# Altium schematic sheet supply.SchDoc(supply)
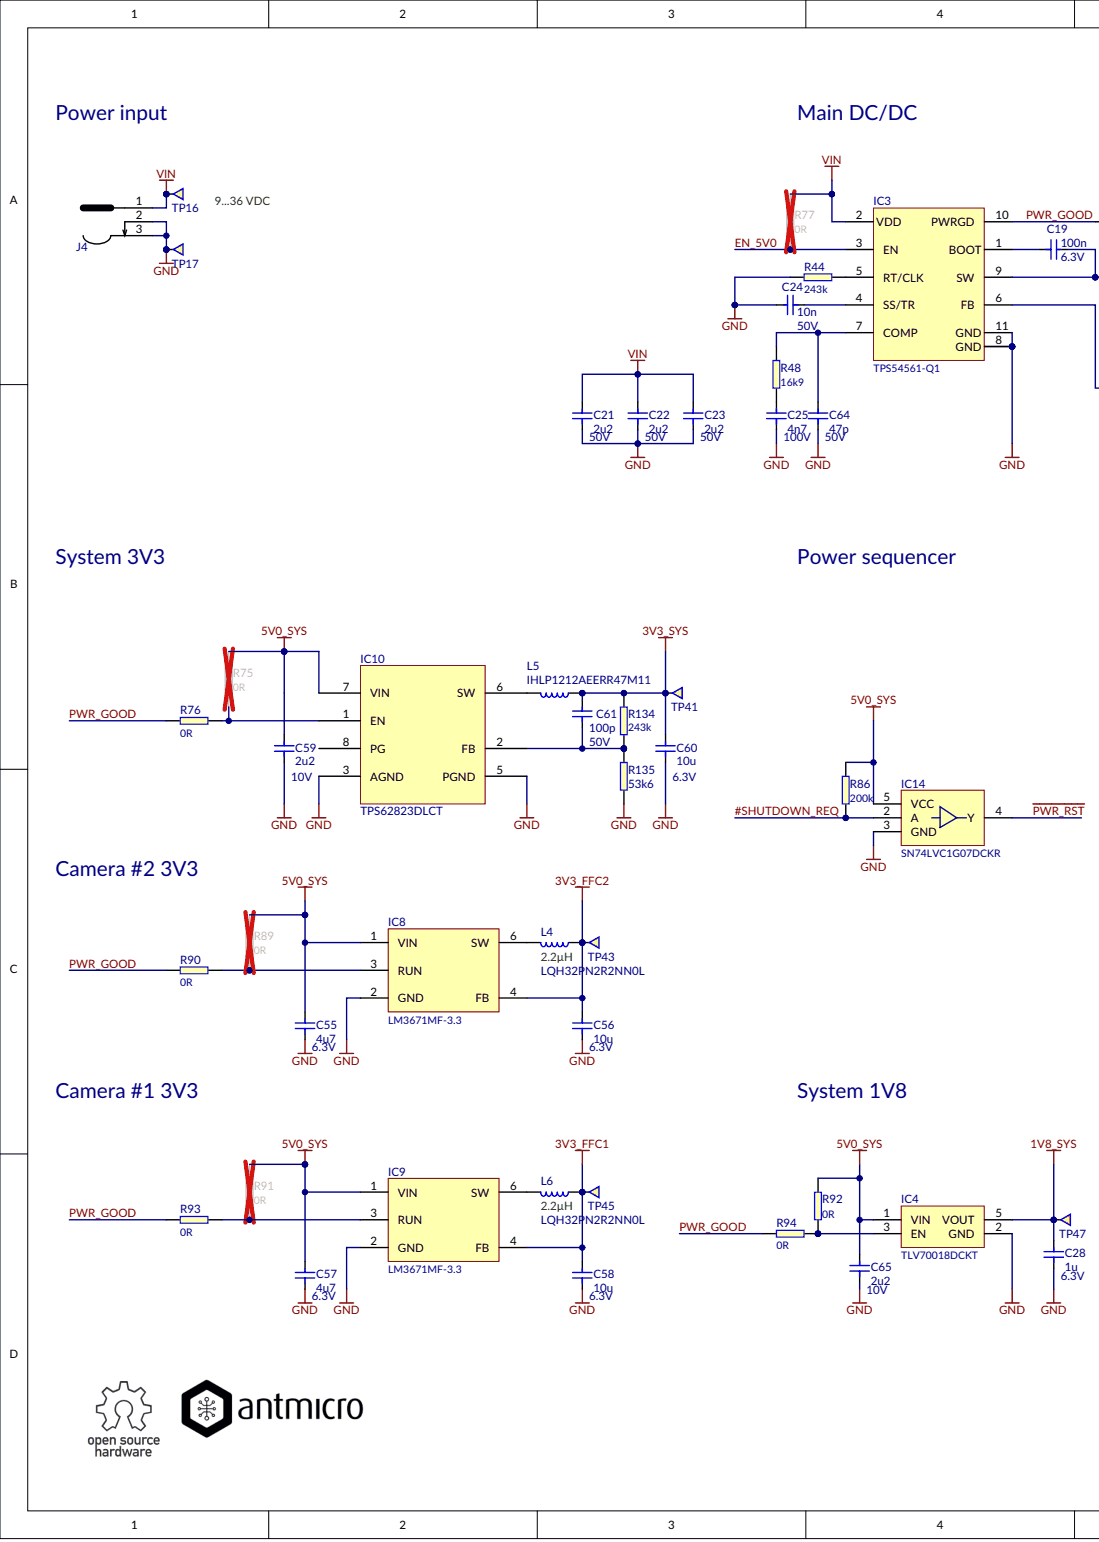
[diagram p0: supply.SchDoc, left]
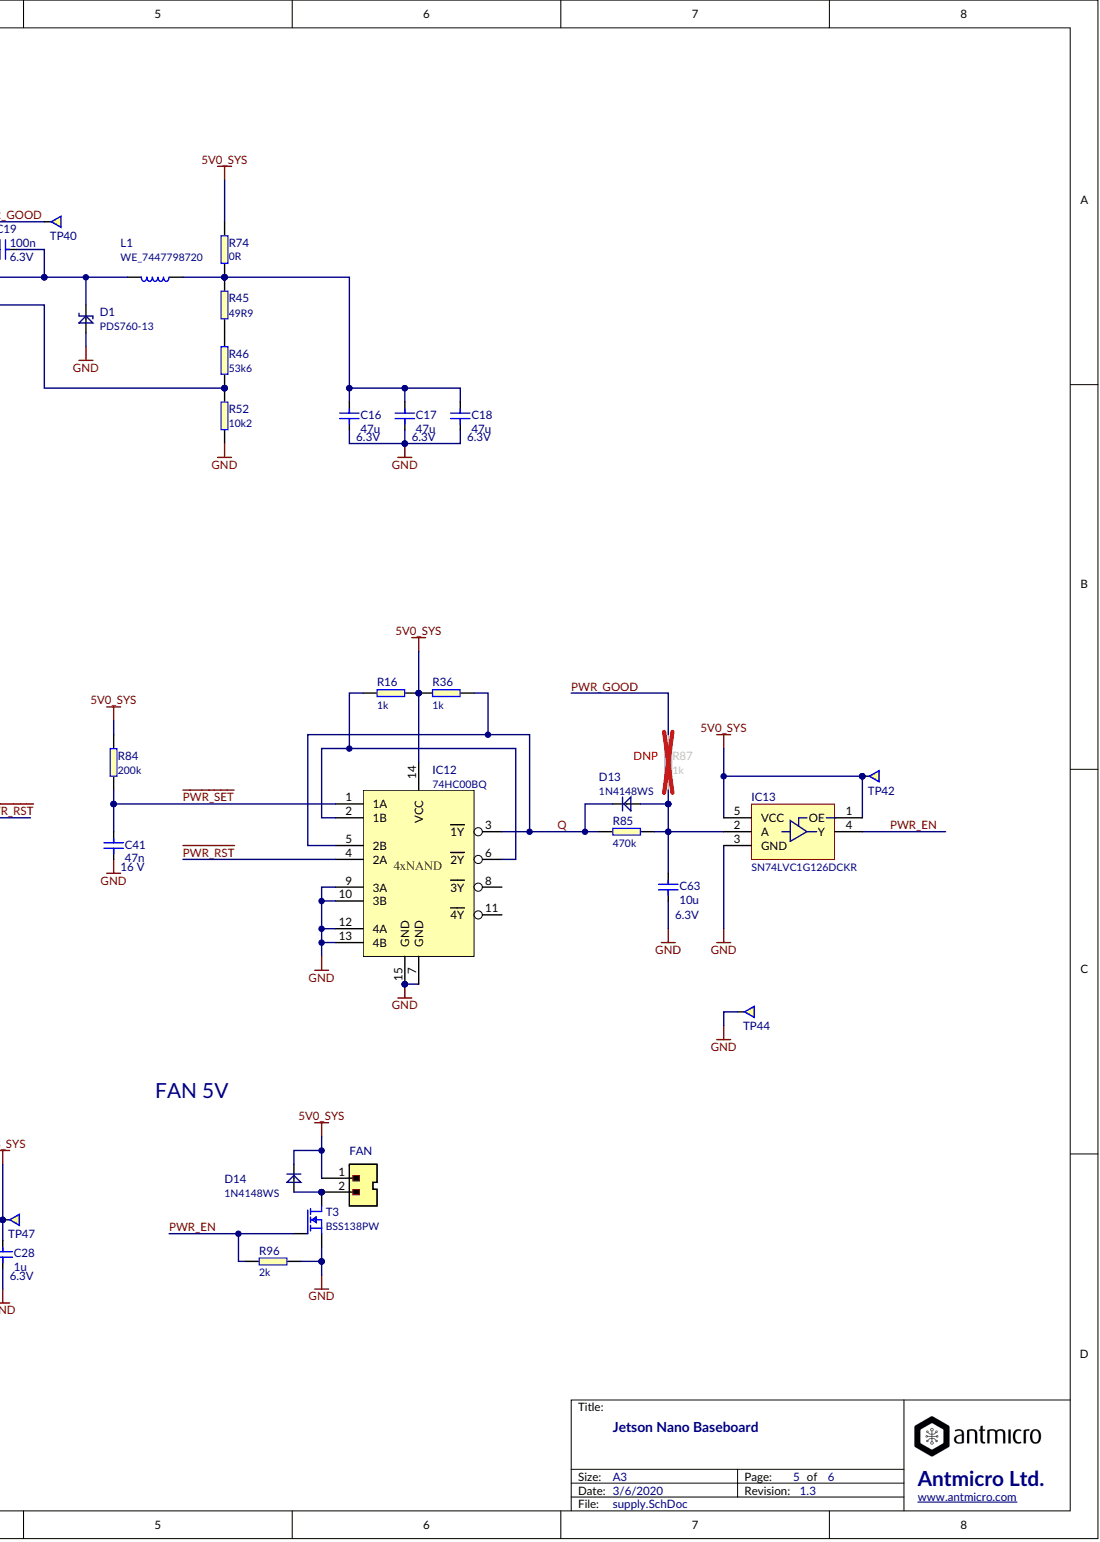
[diagram p1: supply.SchDoc, right]

## Parts
C16  Voltage: 6.3V  (hidden comment: 47u)
C17  Voltage: 6.3V  (hidden comment: 47u)
C18  Voltage: 6.3V  (hidden comment: 47u)
C19  Voltage: 6.3V  (hidden comment: 100n)
C21  Voltage: 50V  (hidden comment: 2u2)
C22  Voltage: 50V  (hidden comment: 2u2)
C23  Voltage: 50V  (hidden comment: 2u2)
C24  Voltage: 50V  (hidden comment: 10n)
C25  Voltage: 100V  (hidden comment: 4n7)
C28  Voltage: 6.3V  (hidden comment: 1u)
C41  Voltage: 16 V  (hidden comment: 47n)
C55  Voltage: 6.3V  (hidden comment: 4u7)
C56  Voltage: 6.3V  (hidden comment: 10u)
C57  Voltage: 6.3V  (hidden comment: 4u7)
C58  Voltage: 6.3V  (hidden comment: 10u)
C59  2u2
C60  Voltage: 6.3V  (hidden comment: 10u)
C61  Voltage: 50V  (hidden comment: 100p)
C63  Voltage: 6.3V  (hidden comment: 10u)
C64  Voltage: 50V  (hidden comment: 47p)
C65  Voltage: 10V  (hidden comment: 2u2)
D1  PDS760-13
D13  1N4148WS
D14  1N4148WS
FAN  MX-22-23-2021  (value not drawn)
IC3  TPS54561-Q1
IC4  TLV70018DCKT
IC8  LM3671MF-3.3
IC9  LM3671MF-3.3
IC10  TPS62823DLCT
IC12  74HC00BQ
IC13  SN74LVC1G126DCKR
IC14  SN74LVC1G07DCKR
J4  MJ-179PH  (value not drawn)
L1  WE_7447798720
L4  LQH32PN2R2NN0L  (value not drawn)
L5  IHLP1212AEERR47M11
L6  LQH32PN2R2NN0L  (value not drawn)
R16  1k
R36  1k
R44  243k
R45  49R9
R46  53k6
R48  16k9
R52  10k2
R74  0R
R75  0R
R76  0R
R77  0R
R84  200k
R85  470k
R86  200k
R87  1k
R89  0R
R90  0R
R91  0R
R92  0R
R93  0R
R94  0R
R96  2k
R134  243k
R135  53k6
T3  BSS138PW
TP16  Testpad  (value not drawn)
TP17  Testpad  (value not drawn)
TP40  Testpad  (value not drawn)
TP41  Testpad  (value not drawn)
TP42  Testpad  (value not drawn)
TP43  Testpad  (value not drawn)
TP44  Testpad  (value not drawn)
TP45  Testpad  (value not drawn)
TP47  Testpad  (value not drawn)

## Nets
1V8_SYS: C28.2 IC4.5(VOUT) TP47.1
3V3_FFC1: C58.2 IC9.4(FB) L6.2 TP45.1
3V3_FFC2: C56.2 IC8.4(FB) L4.2 TP43.1
3V3_SYS: C60.2 C61.2 L5.2 R134.1 TP41.1
5V0_SYS: C55.2 C57.2 C59.2 C65.2 D14.C FAN.1 IC4.1(VIN) IC8.1(VIN) IC9.1(VIN) IC10.7(VIN) IC12.14(VCC) IC13.1(OE) IC13.5(VCC) IC14.5(VCC) R16.1 R36.2 R74.2 R75.2 R84.2 R86.2 R89.2 R91.2 R92.1 TP42.1
GND: C16.1 C17.1 C18.1 C21.1 C22.1 C23.1 C24.2 C25.1 C28.1 C41.1 C55.1 C56.1 C57.1 C58.1 C59.1 C60.1 C63.1 C64.1 C65.1 D1.A IC3.8(GND) IC3.11(GND) IC4.2(GND) IC8.2(GND) IC9.2(GND) IC10.3(AGND) IC10.5(PGND) IC12.7(GND) IC12.9(3A) IC12.10(3B) IC12.12(4A) IC12.13(4B) IC12.15(GND) IC13.3(GND) IC14.3(GND) J4.2 J4.3 R44.2 R52.1 R96.2 R135.1 T3.2 TP17.1 TP44.1
VIN: C21.2 C22.2 C23.2 IC3.2(VDD) J4.1 R77.2 TP16.1
#SHUTDOWN_REQ: IC14.2(A) R86.1
~{PWR_RST}: IC12.4(2A) IC14.4(Y)
~{PWR_SET}: C41.2 IC12.1(1A) R84.1
EN_5V0: IC3.3(EN) R77.1
PWR_EN: IC13.4(Y) R96.1 T3.1
PWR_GOOD: IC3.10(PWRGD) R76.2 R87.1 R90.2 R93.2 R94.2 TP40.1
Q: D13.C IC12.3(1Y) IC12.5(2B) R36.1 R85.1
NetC16_2: C16.2 C17.2 C18.2 L1.2 R45.2 R74.1
NetC19_1: C19.1 IC3.1(BOOT)
NetC19_2: C19.2 D1.C IC3.9(SW) L1.1
NetC24_1: C24.1 IC3.4(SS/TR)
NetC25_2: C25.2 R48.1
NetC61_1: C61.1 IC10.2(FB) R134.2 R135.2
NetC63_2: C63.2 D13.A IC13.2(A) R85.2 R87.2
NetC64_2: C64.2 IC3.7(COMP) R48.2
NetD14_A: D14.A FAN.2 T3.3
NetIC3_5: IC3.5(RT/CLK) R44.1
NetIC3_6: IC3.6(FB) R46.1 R52.2
NetIC4_3: IC4.3(EN) R92.2 R94.1
NetIC8_3: IC8.3(RUN) R89.1 R90.1
NetIC8_6: IC8.6(SW) L4.1
NetIC9_3: IC9.3(RUN) R91.1 R93.1
NetIC9_6: IC9.6(SW) L6.1
NetIC10_1: IC10.1(EN) R75.1 R76.1
NetIC10_6: IC10.6(SW) L5.1
NetIC12_2: IC12.2(1B) IC12.6(2Y) R16.2
NetR45_1: R45.1 R46.2

Not connected: IC10 8(PG); IC12 8(3Y) 11(4Y)

## Library data of the parts
C16-C18 = (no symbol name): PartNumber: C_47u_0805; Manufacturer: KEMET; PartSymbol: C0805C476M9PACTU; Value: 47u
C19 = (no symbol name): Manufacturer: TDK; PartNumber: C_100n_0402; PartSymbol: C1005X5R0J104K050BA; Value: 100n
C21-C23 = (no symbol name): PartNumber: C_2u2_1206; Manufacturer: KEMET; PartSymbol: C1206C225J5RACTU; Value: 2u2
C24 = (no symbol name): PartNumber: C_10n_0402; Manufacturer: AVX; PartSymbol: 04025G103ZAT2A; Value: 10n
C25 = (no symbol name): PartNumber: C_4n7_0402; Manufacturer: TDK; PartSymbol: CGA2B3X7S2A472K050BB; Value: 4n7
C28 = (no symbol name): Manufacturer: MULTICOMP; PartNumber: C_1u_0402; PartSymbol: MC0402F105Z6R3CT; Value: 1u
C41 = (no symbol name): PartNumber: C_47n_0402; Manufacturer: MULTICOMP; PartSymbol: MC0402X473K160CT; Value: 47n
C55 C57 = (no symbol name): Manufacturer: MULTICOMP; PartNumber: C_4u7_0603; PartSymbol: MC0603X475K6R3CT; Value: 4u7
C56 C58 C60 C63 = (no symbol name): Manufacturer: Walsin; PartNumber: C_10u_0603; PartSymbol: 0603X106M6R3CT; Value: 10u
C59 = (no symbol name): Manufacturer: TDK; PartNumber: C_2u2_0402; PartSymbol: C1005X5R1A225K050BC; Voltage: 10V; Value: 2u2
C61 = (no symbol name): PartNumber: C_100p_0402; Manufacturer: WALSIN; PartSymbol: 0402N101J500CT; Class: Capacitor; Value: 100p
C64 = (no symbol name): PartNumber: C_47p_0402; Manufacturer: KEMET; PartSymbol: C0402C470J5GACTU; Value: 47p
C65 = (no symbol name): Manufacturer: TDK; PartNumber: C_2u2_0402; PartSymbol: C1005X5R1A225K050BC; Value: 2u2
D1 = (no symbol name): Manufacturer: DIODES INC.; PartNumber: PDS760-13; Value: PDS760-13; PartSymbol: PDS760-13
D13 D14 = (no symbol name): Manufacturer: ON SEMICONDUCTOR; PartNumber: 1N4148WS; Value: 1N4148WS; PartSymbol: 1N4148WS
FAN = (no symbol name): Manufacturer: Molex; PartNumber: molex_2223-2021; PartSymbol: 022232021 A-6373-02A222; Value: MX-22-23-2021
IC3 IC4 IC13 = (no symbol name): Manufacturer: Texas Instruments
  IC3: PartNumber: TPS54561-Q1; Value: TPS54561-Q1; PartSymbol: TPS54561-Q1
  IC4: Value: TLV70018DCKT; PartNumber: TLV70018DCKT; PartSymbol: TLV70018DCKT
  IC13: PartNumber: SN74LVC1G126DCKR; Value: SN74LVC1G126DCKR; PartSymbol: SN74LVC1G126DCKR
IC8 IC9 = (no symbol name): Manufacturer: Texas Instruments; PartSymbol: LM3671MF-3.3/NOPB; Value: LM3671MF-3.3; PartNumber: LM3671MF-3.3
IC10 = (no symbol name): Manufacturer: TEXAS INSTRUMENTS; Class: IC; PartNumber: TPS62823; Value: TPS62823DLCT; PartSymbol: TPS62823DLCT
IC12 = (no symbol name): Manufacturer: NEXPERIA; PartNumber: 74HC00BQ; Value: 74HC00BQ; PartSymbol: 74HC00BQ
IC14 = (no symbol name): Manufacturer: Texas Instruments; PartSymbol: SN74LVC1G07GW; PartNumber: SN74LVC1G07DCKR; Value: SN74LVC1G07DCKR
J4 = (no symbol name): PartNumber: DC_SOCKET; Manufacturer: MULTICOMP; PartSymbol: MJ-179PH; Value: MJ-179PH
L1 = (no symbol name): Manufacturer: WURTH ELEKTRONIK; RatedCurrent: 7.9A; Inductance: 7.2uH; PartNumber: WE_7447798720; Value: WE_7447798720; PartSymbol: 7447798720
L4 L6 = (no symbol name): Manufacturer: MURATA; Inductance: 2.2uH; PartNumber: LQH32PN2R2NN0L; Value: LQH32PN2R2NN0L; PartSymbol: LQH32PN2R2NN0L
L5 = (no symbol name): Manufacturer: VISHAY; RatedCurrent: 6.7A; Inductance: 470nH; Class: Inductance; PartNumber: IHLP1212AEERR47M11; Value: IHLP1212AEERR47M11; PartSymbol: IHLP1212AEERR47M11
R16 R36 R87 = (no symbol name): PartNumber: R_1k_0402; Manufacturer: BOURNS; PartSymbol: CR0402-FX-1001GLF; Value: 1k
R44 R134 = (no symbol name): PartNumber: R_243k_0402; Manufacturer: MULTICOMP; PartSymbol: MCMR04X2433FTL; Value: 243k
R45 = (no symbol name): PartNumber: R_49R9_0402; Manufacturer: VISHAY; PartSymbol: CRCW040249R9FKED; Value: 49R9
R46 = (no symbol name): PartNumber: R_53k6_0402; Manufacturer: TE Connectivity; PartSymbol: CPF0402B53K6E1; Value: 53k6
R48 = (no symbol name): PartNumber: R_16k9_0402; Manufacturer: Panasonic; PartSymbol: ERA2AEB1692X; Value: 16k9
R52 = (no symbol name): PartNumber: R_10k2_0402_0.1%; Manufacturer: TE Connectivity; PartSymbol: CPF0402B10K2E1; Tolerance: 0.1%; Value: 10k2
R74 = (no symbol name): Manufacturer: Panasonic; PartNumber: R_0R_0402; PartSymbol: ERJ2GE0R00X; Value: 0R
R75-R77 R89-R94 = (no symbol name): PartNumber: R_0R_0402; Manufacturer: Panasonic; PartSymbol: ERJ2GE0R00X; Value: 0R
R84 R86 = (no symbol name): PartNumber: R_200k_0402; Manufacturer: YAGEO; PartSymbol: RC0402FR-07200KL; Value: 200k
R85 = (no symbol name): PartNumber: R_470k_0603; Manufacturer: VISHAY; PartSymbol: CRCW0603470KFKEA; Value: 470k
R96 = (no symbol name): PartNumber: R_2k_0402; Manufacturer: VISHAY; PartSymbol: CRCW04022K00FKED; Value: 2k
R135 = (no symbol name): PartNumber: R_53k6_0402; Manufacturer: TE CONECTIVITY; PartSymbol: CPF0402B53K6E1; Value: 53k6
T3 = (no symbol name): Manufacturer: NXP; Value: BSS138PW; PartNumber: BSS138PW; PartSymbol: BSS138PW
TP16 TP17 TP40-TP45 TP47 = (no symbol name): PartNumber: TP-R-1.5
